# Altium schematic sheet Light Main.SchDoc("Light Main")
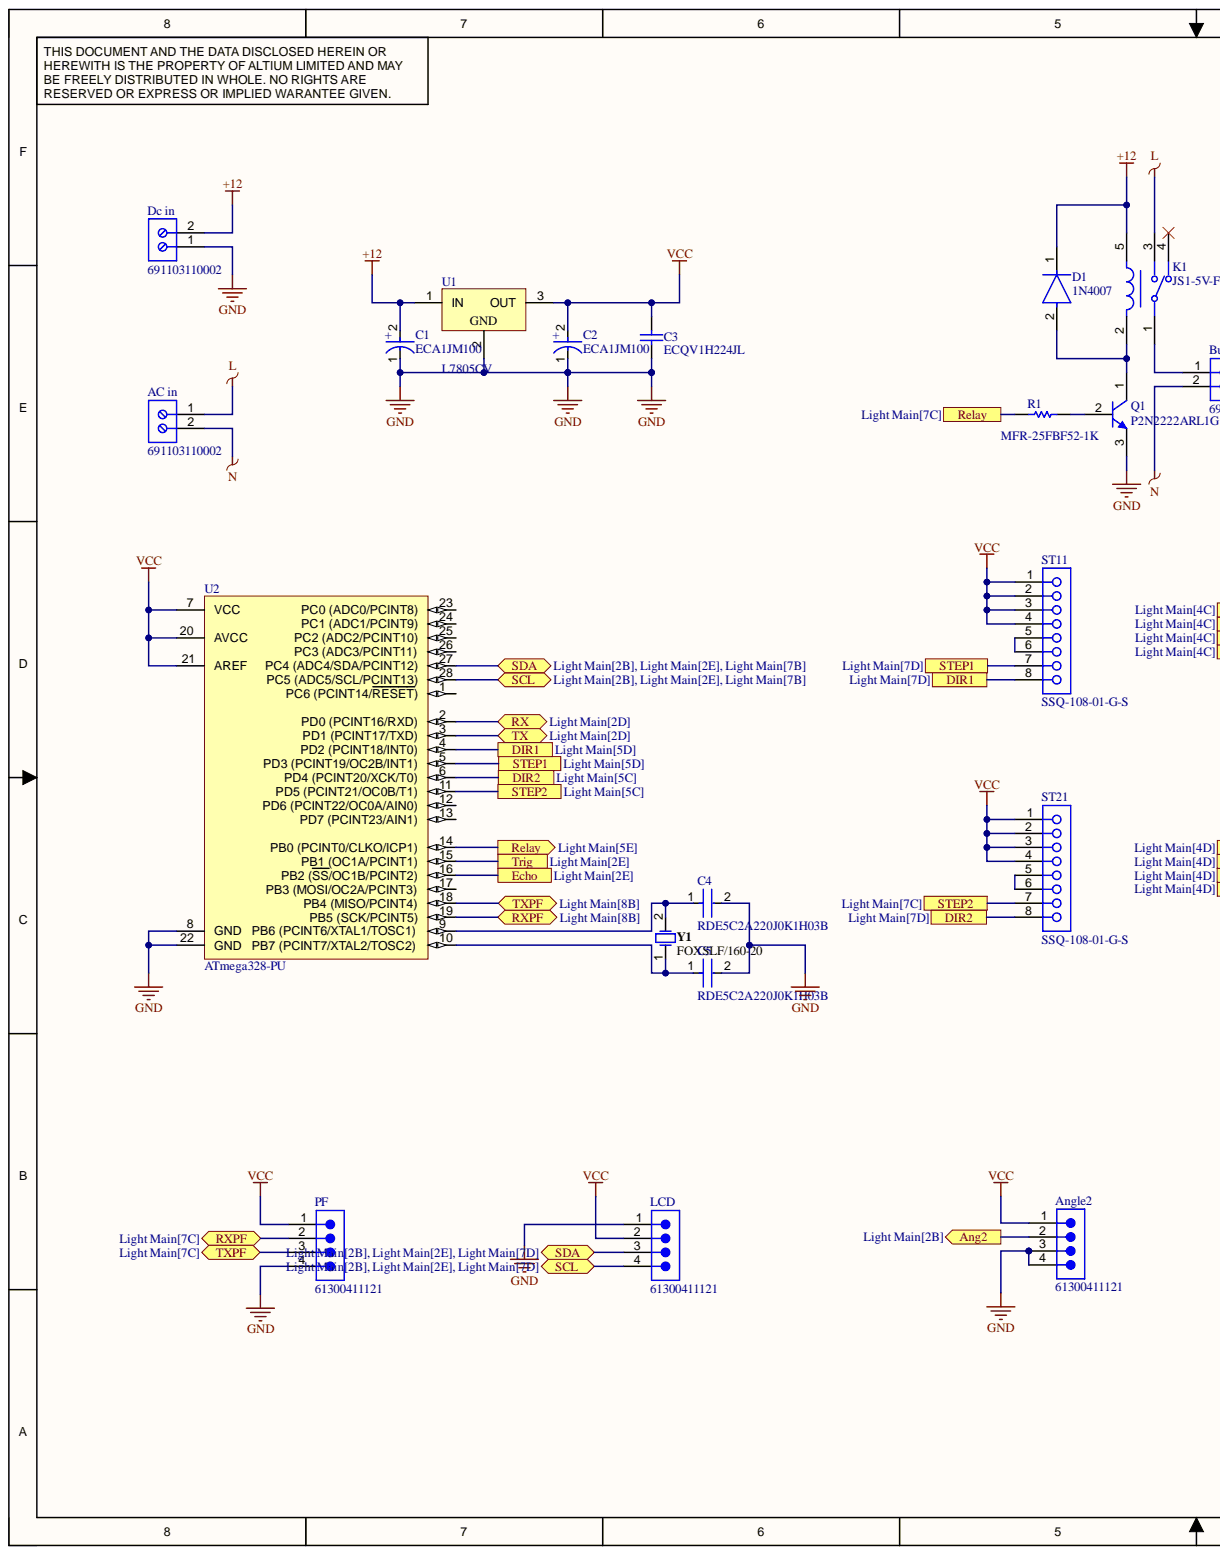
[diagram p0: Light Main.SchDoc, left]
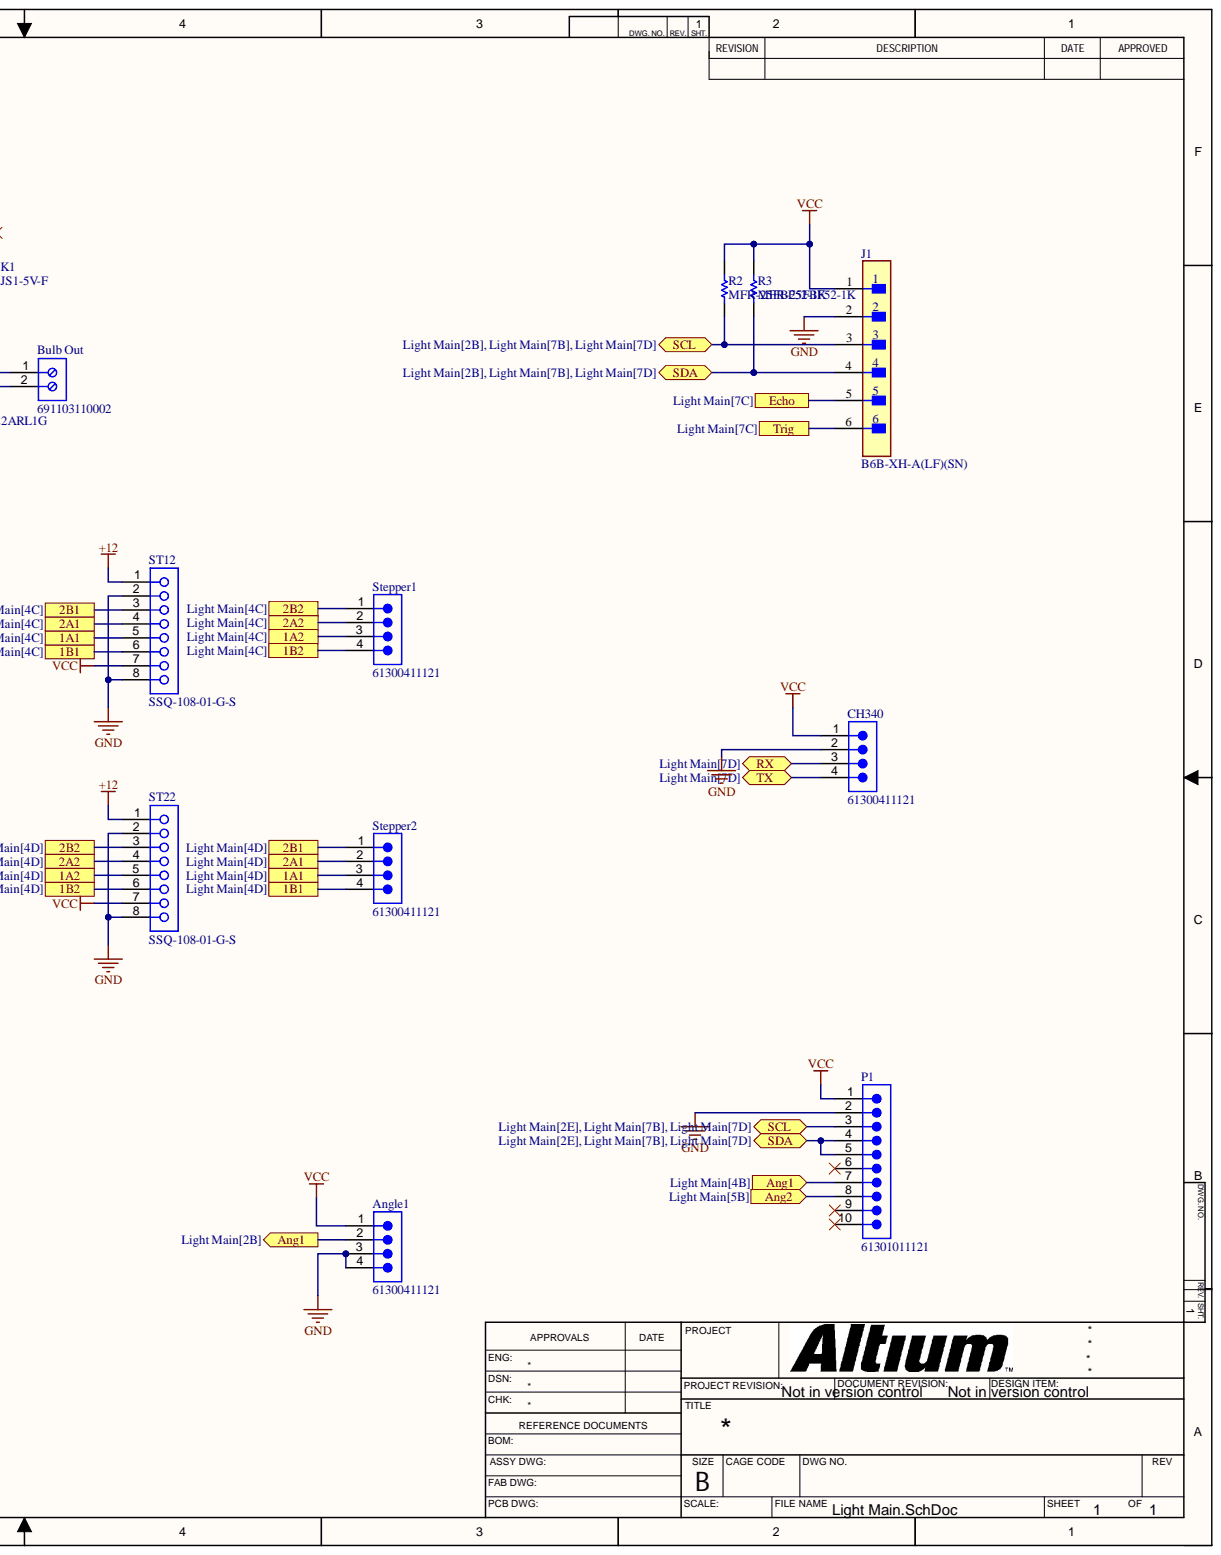
[diagram p1: Light Main.SchDoc, right]

## Parts
AC_in  691103110002  [691103110002]
Angle1  61300411121  [61300411121]
Angle2  61300411121  [61300411121]
Bulb_Out  691103110002  [691103110002]
C1  ECA1JM100  [FP-RAD-TH-D_5_0_5-L_11_1-MFG]
C2  ECA1JM100  [FP-RAD-TH-D_5_0_5-L_11_1-MFG]
C3  ECQV1H224JL  [PNSC-ECQV1H224JL]
C4  RDE5C2A220J0K1H03B  [FP-RDE0M1-MFG]
C5  RDE5C2A220J0K1H03B  [FP-RDE0M1-MFG]
CH340  61300411121  [61300411121]
D1  1N4007  [FP-1N4007-MFG]
Dc_in  691103110002  [691103110002]
J1  B6B-XH-A(LF)(SN)  [FP-B6B-XH-A_LF_SN-MFG]
K1  JS1-5V-F  [FP-JS1-5V-F-MFG]
LCD  61300411121  [61300411121]
P1  61301011121  [61301011121]
PF  61300411121  [61300411121]
Q1  P2N2222ARL1G  [ONSC-TO-92-3-29-11_AM_BL]
R1  MFR-25FBF52-1K  [RESA60-630X240]
R2  MFR-25FBF52-1K  [RESA60-630X240]
R3  MFR-25FBF52-1K  [RESA60-630X240]
ST11  SSQ-108-01-G-S  [SMTC-SSQ-108-01-X-S]
ST12  SSQ-108-01-G-S  [SMTC-SSQ-108-01-X-S]
ST21  SSQ-108-01-G-S  [SMTC-SSQ-108-01-X-S]
ST22  SSQ-108-01-G-S  [SMTC-SSQ-108-01-X-S]
Stepper1  61300411121  [61300411121]
Stepper2  61300411121  [61300411121]
U1  L7805CV  [TO220]
U2  ATmega328-PU  [28P3]
Y1  FOXSLF/160-20  [FOX-FOXSLF160-20]

## Ports
1A1, 1A2, 1B1, 1B2, 2A1, 2A2, 2B1, 2B2, Ang1, Ang2, DIR1, DIR2, Echo, Relay, RX, RXPF, SCL, SDA, STEP1, STEP2, Trig, TX, TXPF

## Nets
+12: C1.2 D1.1 Dc_in.2 K1.5 ST12.1 ST22.1 U1.1
GND: Angle1.3 Angle1.4 Angle2.3 Angle2.4 C1.1 C2.1 C3.1 C4.2 C5.2 CH340.2 Dc_in.1 J1.2 LCD.1 P1.2 PF.4 Q1.3 ST12.2 ST12.8 ST22.2 ST22.8 U1.2 U2.8(GND) U2.22(GND)
L: AC_in.1 K1.3
N: AC_in.2 Bulb_Out.2
VCC: Angle1.1 Angle2.1 C2.2 C3.2 CH340.1 J1.1 LCD.2 P1.1 PF.1 R2.2 R3.2 ST11.1 ST11.2 ST11.3 ST11.4 ST12.7 ST21.1 ST21.2 ST21.3 ST21.4 ST22.7 U1.3 U2.7(VCC) U2.20(AVCC) U2.21(AREF)
1A1: ST12.5 Stepper2.3 ; port 1A1
1A2: ST22.5 Stepper1.3 ; port 1A2
1B1: ST12.6 Stepper2.4 ; port 1B1
1B2: ST22.6 Stepper1.4 ; port 1B2
2A1: ST12.4 Stepper2.2 ; port 2A1
2A2: ST22.4 Stepper1.2 ; port 2A2
2B1: ST12.3 Stepper2.1 ; port 2B1
2B2: ST22.3 Stepper1.1 ; port 2B2
Ang1: Angle1.2 P1.7 ; port Ang1
Ang2: Angle2.2 P1.8 ; port Ang2
DIR1: ST11.8 U2.4(PD2_(PCINT18/INT0)) ; port DIR1
DIR2: ST21.8 U2.6(PD4_(PCINT20/XCK/T0)) ; port DIR2
Echo: J1.5 U2.16(PB2_(SS/OC1B/PCINT2)) ; port Echo
Relay: R1.1 U2.14(PB0_(PCINT0/CLKO/ICP1)) ; port Relay
RX: CH340.3 U2.2(PD0_(PCINT16/RXD)) ; port RX
RXPF: PF.2 U2.19(PB5_(SCK/PCINT5)) ; port RXPF
SCL: J1.3 LCD.4 P1.3 R2.1 U2.28(PC5_(ADC5/SCL/PCINT13)) ; port SCL
SDA: J1.4 LCD.3 P1.4 P1.5 R3.1 U2.27 ; port SDA
STEP1: ST11.7 U2.5(PD3_(PCINT19/OC2B/INT1)) ; port STEP1
STEP2: ST21.7 U2.11(PD5_(PCINT21/OC0B/T1)) ; port STEP2
Trig: J1.6 U2.15(PB1_(OC1A/PCINT1)) ; port Trig
TX: CH340.4 U2.3(PD1_(PCINT17/TXD)) ; port TX
TXPF: PF.3 U2.18(PB4_(MISO/PCINT4)) ; port TXPF
NetBulb Out_1: Bulb_Out.1 K1.1
NetC4_1: C4.1 U2.9 Y1.2
NetC5_1: C5.1 U2.10 Y1.1
NetD1_2: D1.2 K1.2 Q1.1
NetQ1_2: Q1.2 R1.2
NetST11_5: ST11.5 ST11.6
NetST21_5: ST21.5 ST21.6

Not connected: K1 4; P1 6 9 10; U2 1(PC6_(PCINT14/RESET)) 12(PD6_(PCINT22/OC0A/AIN0)) 13(PD7_(PCINT23/AIN1)) 17(PB3_(MOSI/OC2A/PCINT3)) 23 24(PC1_(ADC1/PCINT9)) 25 26(PC3_(ADC3/PCINT11))

## Library data of the parts
AC_in Bulb_Out Dc_in = CMP-1502-03254-2: NexarPartId: 18818286; Current: 10A; Number of Contacts: 2; Number of Levels: 1; Pitch: 3.5052mm; Plating: Steel; Stripping Length: -6mm; Voltage: 125V; Wire Gauge (Max): 26AWG; Wire Gauge (Min): 16AWG; Color: Blue; Component Kind: Standard; Contact Material: Brass, Copper, Nickel, Tin, Zinc; Contact Plating: Tin; Current Rating: 10A; Height: 8.5mm; Housing Material: Nylon; Lead Pitch: 3.5mm; Length: 7mm; Library Name: Altium Content Vault; Max Operating Temperature: 105°C; Min Operating Temperature: -40°C; Mount: Through Hole; Number of Pins: 2; Number of Positions Per Level: 2; Number of Rows: 1; Packaging: Box; REACH SVHC: Unknown; RoHS: Compliant; Termination: Screw; Voltage Rating: 125V; Width: 8mm; Wire/Cable Gauge: 26AWG
Angle1 Angle2 CH340 LCD PF Stepper1 Stepper2 = CMP-1502-01064-3: NexarPartId: 32855522; Connector Type: Male Pin; Contact Resistance: 20mΩ; Current Rating: 3A; Gender: Male; Number of Contacts: 4; Operating Supply Voltage: 250V; Pitch: 2.54mm; Color: Black; Component Kind: Standard; Contact Material: Copper; Contact Mating Length: 5.9944mm; Contact Plating: Gold; Height: 8.89mm; Lead Free: Lead Free; Lead Pitch: 2.54mm; Library Name: Altium Content Vault; Max Operating Temperature: 105°C; Min Operating Temperature: -40°C; Mount: Through Hole; Number of Pins: 4; Number of Positions: 4; Number of Rows: 1; Orientation: Vertical; Packaging: Bulk; REACH SVHC: Unknown; RoHS: Compliant; Termination: Solder; Voltage Rating: 250V
C1 C2 = CMP-05427-001517-1: Aluminum Electrolytic Capacitors (Radial Lead Type) 10uF ±20% 63V; Dielectric Material: Aluminium; Dissipation Factor: 0.11%; Lead Diameter: 0.5mm; Lead/Base Style: Straight; Leakage Current: 3uA; Life (Hours): 2000Hour; Ripple Current: 70mA; Capacitance: 10uF; Case/Package: Radial; Component Kind: Standard; Construction: RADIAL; Diameter: 5mm; Height: 11mm; Height - Seated (Max): 0.472inch; Lead Free: Lead Free; Lead Pitch: 2mm; Length: 11mm; Library Name: Altium Content Vault; Max Operating Temperature: 85°C; Min Operating Temperature: -40°C; Mount: Through Hole; Package Quantity: 200; Packaging: Bulk; Radiation Hardening: No; REACH SVHC: No SVHC; RoHS Compliant: Yes; Series: M; Termination: Radial; Tolerance: 20%; Voltage: 63V; Voltage Rating: 63V; Voltage Rating (DC): 63V; Width: 5mm
C3 = CMP-2000-06190-1: Stacked Metallized Plastic Film Capacitor, 220 nF, +/- 5%, 50 V, -40 to 105 degC, 2-Pin THD, RoHS, Bulk; Manufacturer: Panasonic; Capacitance: 0.22µF; Capacitor Dielectric Type: Polyester(PET); Capacitor Mounting: ThroughHole; Capacitor Terminals: RadialLeaded; External Depth: 4.8mm; External Length / Height: 5.5mm; External Width: 7.3mm; Lead Diameter: 0.5mm; Lead Length: 20mm; No. of Pins: 2; Product Range: PANASONIC-ECQVSeries; SVHC: NoSVHC(16-Jun-2014); Voltage Rating: 50V; Capacitance Tolerance: ±5%; Capacitance Tolerance ±: ±5%; Component Kind: Standard; Datasheet URL: https://industrial.panasonic.com/cdbs/www-data/pdf/RDI0000/DMI0000COL8.pdf; Datasheet Version: 04/2015; Height: 5.5mm; Lead Spacing: 5mm; Library Name: Altium Content Vault; Manufacturer URL: http://www.panasonic.com/; Mounting Technology: ThroughHole; Operating Temperature Max: 105°C; Operating Temperature Min: -40°C; Operating Temperature Range: -40°Cto+105°C; Package Description: 2-Pin Through Hole Device, Body 7.3 x 4.8 mm, Pitch 5 mm; Package Reference: ECQV1H224JL; Packaging: Each
C4 C5 = CMP-06035-017822-1: Ceramic Capacitor 22pF ±5% 100V C0G Radial; Lead Diameter: 0.5mm; Capacitance: 22pF; Case/Package: Radial; Component Kind: Standard; Dielectric: C0G; Height: 3.5mm; Height - Seated (Max): 0.236inch; Lead Pitch: 0.197inch; Length: 0.157inch; Library Name: Altium Content Vault; Max Operating Temperature: 125°C; Min Operating Temperature: -55°C; Mount: Through Hole; Package Quantity: 500; Packaging: Bulk; Resistor Type: Ceramic; Termination: Radial; Tolerance: 5%; Voltage Rating: 100V; Voltage Rating (DC): 100V; Width: 3.5mm
D1 = CMP-123542-000001-1: Rectifier diode 1000 V 1 A DO-41, ,; Manufacturer: Diotec; Capacitance: 15pF; Forward Current: 1A; Max Junction Temp: +175°C; Max Repetitive Reverse Voltage: 1000V; Max Surge Forward Current: 27A; Peak Reverse Current: 5uA; Value: 15pF; Automotive: No; Category: Diode; Component Kind: Standard; ComponentLink2Description: Datasheet; ComponentLink2URL: https://datasheet.octopart.com/1N4007-Diotec-datasheet-62346875.pdf; Device Class L1: Discrete Semiconductors; Device Class L2: Diodes; Device Class L3: Rectifier Diodes; Height: 2.8mm; Ipc Land Pattern Name: DIOAD888W100L520D280; Lead Free: Yes; Library Name: Altium Content Vault; Manufacturer Part Number: 1N4007; Octopart: https://octopart.com/1n4007-diotec-4040411; Package: 1N4007; Reverse Voltage: 1000V; RoHS: TRUE; Temperature: -50°C to +175°C; Temperature Range High: +175°C; Temperature Range Low: -50°C
J1 = CMP-17439-000076-1: CONN HEADER VERT 6POS 2.5MM; Component Kind: Standard; Library Name: Altium Content Vault
K1 = CMP-05606-000016-1: RELAY GEN PURPOSE SPDT 10A 5V; Manufacturer: Panasonic; Coil Current: 72mA; Coil Power: 360mW; Coil Resistance: 69.4Ω; Coil Type: Non-Latching; Number Of Contacts: 1; Standoff Height: 0.3mm; Switching Power: 150W; Ambient Temperature Range High: +70°C; Ambient Temperature Range Low: -40°C; Automotive: No; Category: Relay; Coil Voltage: 5V; Component Kind: Standard; ComponentLink2Description: Datasheet; ComponentLink2URL: https://www3.panasonic.biz/ac/e_download/control/relay/power/catalog/mech_eng_js.pdf?via=ok; Device Class L1: Electromechanical; Device Class L2: Relays; Device Class L3: Power Relays; Digikey Description: RELAY GEN PURPOSE SPDT 10A 5V; Height: 16.8mm; Lead Free: Yes; Library Name: Altium Content Vault; Manufacturer Part Number: JS1-5V-F; Mouser Description: JS Series 10 A SPDT 5 V Through Hole Sealed Miniature Non-Polarized Power Relay; Octopart: https://octopart.com/js1-5v-f-panasonic-39428621; Package: JS1-5V-F; RoHS: TRUE; Switching Current: 10A; Temperature: -40°C to +70°C; Temperature Range High: +70°C; Temperature Range Low: -40°C; Throw Configuration: SPDT
P1 = CMP-1502-01082-5: NexarPartId: 18818159; Connector Type: Male Pin; Contact Resistance: 20mΩ; Current Rating: 3A; Gender: Male; Insulation Resistance: 1GΩ; Number of Contacts: 10; Operating Supply Voltage: 250V; Pitch: 2.54mm; Color: Black; Component Kind: Standard; Contact Gender: Male; Contact Material: Copper; Contact Mating Length: 5.9944mm; Contact Plating: Gold; Flammability Rating: UL94 V-0; Height: 8.74mm; Housing Material: PBT; Lead Pitch: 2.54mm; Length: 2.54mm; Library Name: Altium Content Vault; Max Operating Temperature: 105°C; Min Operating Temperature: -40°C; Mount: Through Hole; Number of Pins: 10; Number of Positions: 10; Number of Rows: 1; Orientation: Vertical; Packaging: Bulk; REACH SVHC: Unknown; RoHS: Compliant; Termination: Solder; Voltage Rating: 250V; Width: 25.4mm
Q1 = CMP-1048-01587-1: Amplifier Transistor, NPN Silicon, 3-Pin TO-92, Pb-Free, Tape and Reel; Manufacturer: On Semiconductor; fT Min (MHz): 300; IC Continuous (A): 0.6; Component Kind: Standard; ComponentLink1Description: Manufacturer URL; ComponentLink1URL: http://www.onsemi.com/; ComponentLink2Description: Datasheet; ComponentLink2URL: http://www.onsemi.com/pub_link/Collateral/P2N2222A-D.PDF; ComponentLink3Description: Package Specification; ComponentLink3URL: http://www.onsemi.com/pub_link/Collateral/MAC97-D.PDF; DatasheetVersion: Rev. 7; Library Name: Altium Content Vault; Mounting Technology: Through Hole; PackageDescription: 3-Pin Transistor Outline, Body 4.825 x 3.685 mm, Pitch 1.27 mm; PackageReference: TO-92-3-29-11_AM_BL; PackageVersion: Rev. AM; Packing: Tape and Reel; PartNumber: P2N2222ARL1G; Polarity: NPN; RoHS: TRUE
R1-R3 = CMP-1659-00075-1: Axial Resistor, 1 KOhm, +/- 1%, 250 mW, -55 to 155 degC, 2-Pin THD, RoHS, Bulk; Power Rating: 250 mW; Resistance: 10 kohm; Voltage Rating: 250 V; Case\Package: Axial; Component Kind: Standard; ComponentLink1Description: Manufacturer URL; ComponentLink1URL: http://www.yageo.com/; ComponentLink2Description: Datasheet; ComponentLink2URL: http://www.yageo.com/documents/recent/Yageo%20LR_MFR_2013.pdf; DatasheetVersion: Apr-13; Length: 0.248 inch; Library Name: Altium Content Vault; Max Operating Temperature: 155 degC; Min Operating Temperature: -55 degC; Package Quantity: 200; PackageDescription: 2-Pin Axial Lead, Dia 2.4 mm, Body Length 6.3 mm; PackageReference: MFR-25; Packaging: Bulk; RoHSCompliant: true; Tolerance: 1%
ST11 ST12 ST21 ST22 = CMP-1023-00292-1: SQ Post Socket, Through-hole, Vertical, -55 to 125 degC, 2.54 mm Pitch, 8-Pin, Female, RoHS; Manufacturer: Samtec; Pitch: 2.54 mm; Component Kind: Standard; ComponentLink1Description: Manufacturer URL; ComponentLink1URL: http://www.samtec.com; ComponentLink2Description: Datasheet; ComponentLink2URL: http://www.samtec.com/documents/webfiles/pdf/SSQ_TH.PDF; ComponentLink3Description: Package Specification; ComponentLink3URL: http://www.samtec.com/documents/webfiles/cpdf/SSQ-1XX-XX-XXX-X-XX-XXX-XX-X-MKT.pdf; DatasheetVersion: F-214; Library Name: Altium Content Vault; Mounting Technology: Through-hole; Orientation: Vertical; PackageDescription: Assembly, Socket Strip .025[0.64] SQ Tail; PackageReference: SSQ-108-01-X-S; PackageVersion: BD, 6/1987; PartNumber: SSQ-108-01-G-S; RoHS: True; Temperature Range: -55 to 125 degC
U1 = CMP-0244-00483-1: Positive Voltage Regulator, 5V, 3-Pin TO-220; Component Kind: Standard; ComponentLink1Description: Manufacturer URL; ComponentLink1URL: http://www.st.com; ComponentLink2Description: Datasheet; ComponentLink2URL: http://www.st.com/internet/com/TECHNICAL_RESOURCES/TECHNICAL_LITERATURE/DATASHEET/CD00000444.pdf; ComponentLink3Description: Package Specification; ComponentLink3URL: http://www.st.com/internet/com/TECHNICAL_RESOURCES/TECHNICAL_LITERATURE/PACKAGE_INFORMATION/CD00004649.pdf; DatasheetVersion: 16/11/2011; Library Name: Altium Content Vault; PackageDescription: TO, Thru-Hole, Vertical, Heatsink Mounted; 3 In-Line Leads; Pitch 2.54 mm; PackageReference: TO220; PackageVersion: ver3.0
U2 = CMP-0095-00015-2: 8-bit AVR Microcontroller, 32KB Flash, 1KB EEPROM, 2KB SRAM, 28-pin PDIP, Industrial Grade (-40°C to 85°C); Component Kind: Standard; ComponentLink1Description: Manufacturer URL; ComponentLink1URL: http://www.atmel.com/; ComponentLink2Description: ATmega48A/48PA/88A/88PA/168A/168PA/328/328P Datasheet; ComponentLink2URL: http://www.atmel.com/dyn/resources/prod_documents/8271.pdf; ComponentLink3Description: ATmega48A/48PA/88A/88PA/168A/168PA/328/328P Summary; ComponentLink3URL: http://www.atmel.com/dyn/resources/prod_documents/8271S.pdf; DatasheetVersion: revD, May-2011; Library Name: Altium Content Vault; PackageDescription: 28-lead (0.300"/7.62mm Wide) Plastic Dual Inline Package (PDIP); PackageReference: 28P3; PackageVersion: revB, Sep-2001; RevisionNote: DatasheetVersion updated. URLs added.
Y1 = CMP-1749-00003-1: Resistance Weld Thru-Hole Crystal, 16 MHz, -20 to 70 degC, 2-Pin THD, RoHS, Bulk; Case\Package: Axial; Power Rating: 250 mW; Resistance: 221 kohm; Tolerance: 1%; Voltage Rating: 250 V; Component Kind: Standard; ComponentLink1Description: Manufacturer URL; ComponentLink1URL: http://www.foxonline.com/; ComponentLink2Description: Datasheet; ComponentLink2URL: http://www.foxonline.com/pdfs/hc49slf.pdf; DatasheetVersion: Mar-15; Length: 0.248 inch; Library Name: Altium Content Vault; Max Operating Temperature: 155 degC; Min Operating Temperature: -55 degC; Mount: Through Hole; Package Quantity: 200; PackageDescription: 2-Pin Through Hole Device, Body 11.35 x 4.65 mm; PackageReference: FOXSLF160-20; Packaging: Bulk; RoHSCompliant: true
